# Altium schematic sheet Motors.SchDoc(Motors)
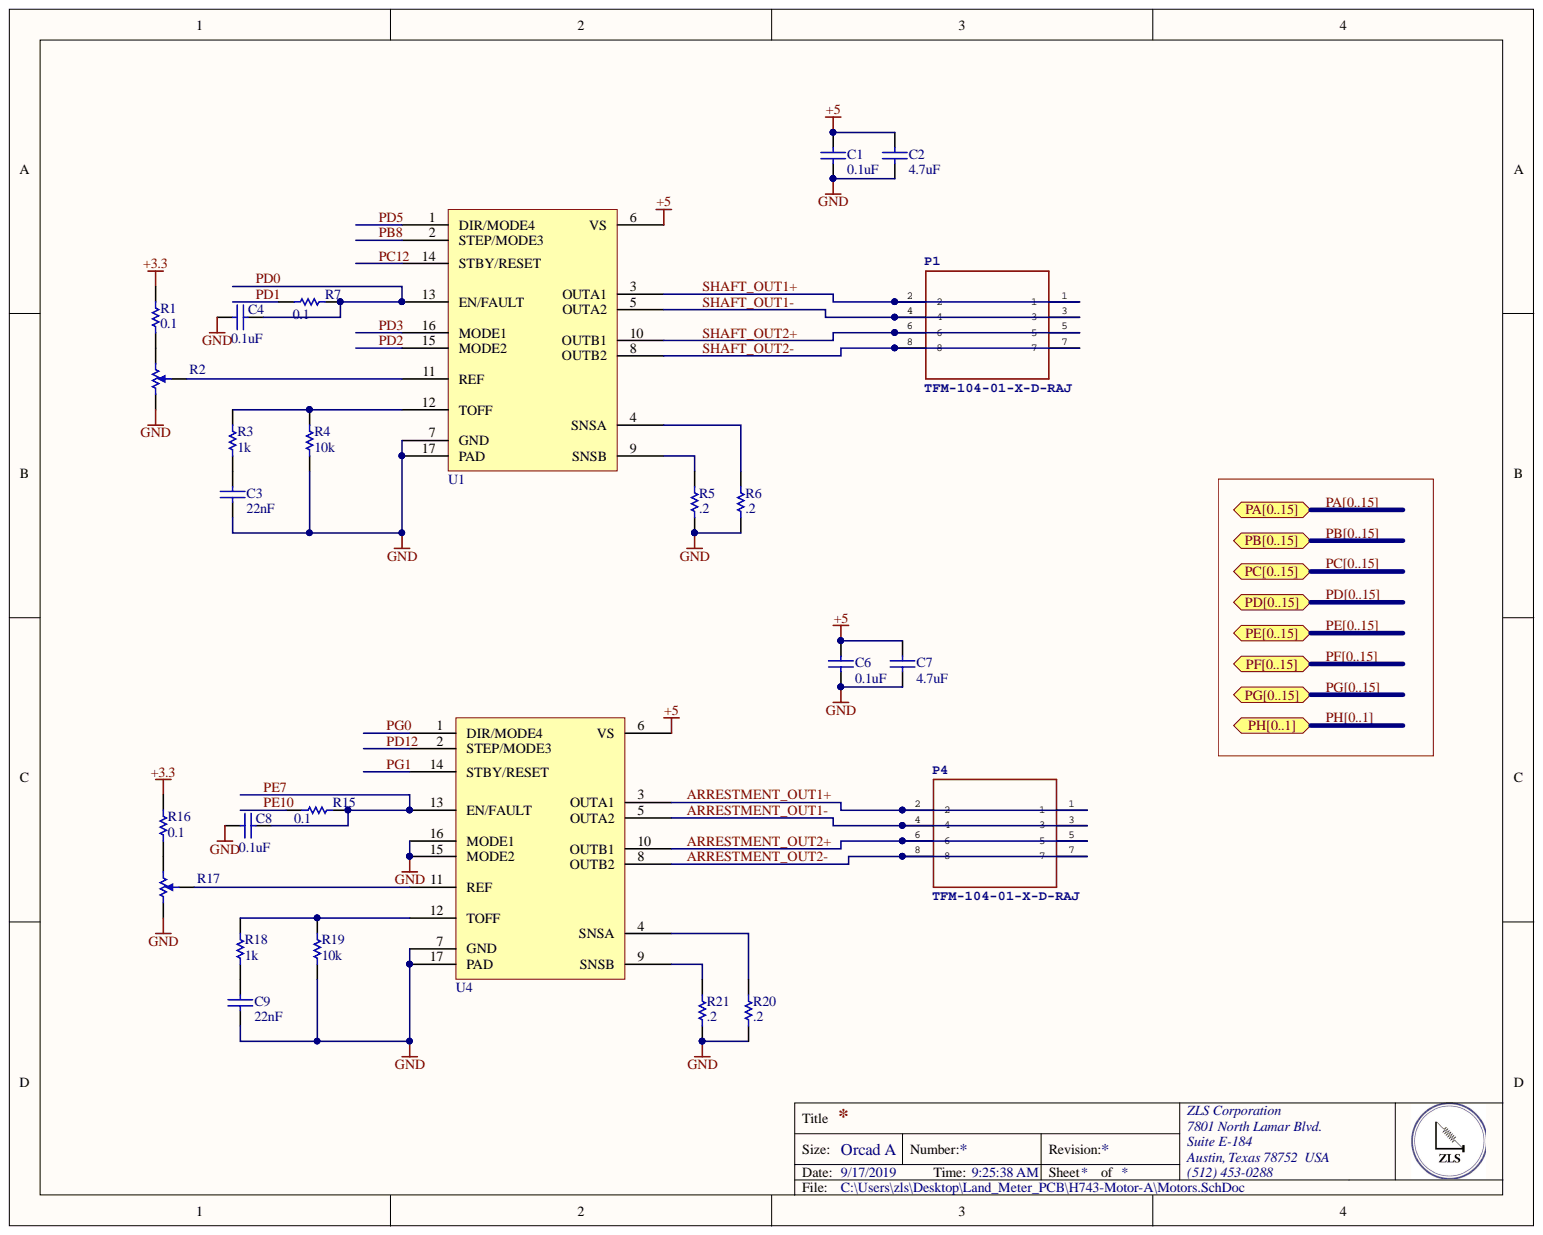
[diagram p0: Motors.SchDoc]

## Parts
C1  0.1uF  [CAPC1608X94X35LL15T15]
C2  4.7uF  [CAPC0603(1608)55_M]
C3  22nF  [CAPC1608X94X35LL15T15]
C4  0.1uF  [CAPC1608X94X35LL15T15]
C6  0.1uF  [CAPC1608X94X35LL15T15]
C7  4.7uF  [CAPC0603(1608)55_M]
C8  0.1uF  [CAPC1608X94X35LL15T15]
C9  22nF  [CAPC1608X94X35LL15T15]
P1  TFM-104-01-X-D-RAJ  [SAMTEC-TFM-104-01-X-D-RA]
P4  TFM-104-01-X-D-RAJ  [SAMTEC-TFM-104-01-X-D-RA]
R1  0.1  [RESC0603(1608)_N]
R2  3313J-1-503E  [BOUR-3313J-1_V]  (value not drawn)
R3  1k  [RESC0603(1608)_N]
R4  10k  [RESC0603(1608)_N]
R5  .2  [RESC0603(1608)_N]
R6  .2  [RESC0603(1608)_N]
R7  0.1  [RESC0603(1608)_N]
R15  0.1  [RESC0603(1608)_N]
R16  0.1  [RESC0603(1608)_N]
R17  3313J-1-503E  [BOUR-3313J-1_V]  (value not drawn)
R18  1k  [RESC0603(1608)_N]
R19  10k  [RESC0603(1608)_N]
R20  .2  [RESC0603(1608)_N]
R21  .2  [RESC0603(1608)_N]
U1  STSPIN220  [STSPIN220-QFN127P600-8N]  (value not drawn)
U4  STSPIN220  [STSPIN220-QFN127P600-8N]  (value not drawn)

## Ports
PA0, PA1, PA2, PA3, PA4, PA5, PA6, PA7, PA8, PA9, PA10, PA11, PA12, PA13, PA14, PA15, PA[0..15], PB0, PB1, PB2, PB3, PB4, PB5, PB6, PB7, PB8, PB9, PB10, PB11, PB12, PB13, PB14, PB15, PB[0..15], PC0, PC1, PC2, PC3, PC4, PC5, PC6, PC7, PC8, PC9, PC10, PC11, PC12, PC13, PC14, PC15, PC[0..15], PD0, PD1, PD2, PD3, PD4, PD5, PD6, PD7, PD8, PD9, PD10, PD11, PD12, PD13, PD14, PD15, PD[0..15], PE0, PE1, PE2, PE3, PE4, PE5, PE6, PE7, PE8, PE9, PE10, PE11, PE12, PE13, PE14, PE15, PE[0..15], PF0, PF1, PF2, PF3, PF4, PF5, PF6, PF7, PF8, PF9, PF10, PF11, PF12, PF13, PF14, PF15, PF[0..15], PG0, PG1, PG2, PG3, PG4, PG5, PG6, PG7, PG8, PG9, PG10, PG11, PG12, PG13, PG14, PG15, PG[0..15], PH0, PH1, PH[0..1]

## Nets
+3.3: R1.1 R16.1
+5: C1.1 C2.2 C6.1 C7.2 U1.6(VS) U4.6(VS)
GND: C1.2 C2.1 C3.2 C4.1 C6.2 C7.1 C8.1 C9.2 R2.1 R4.1 R5.1 R6.1 R17.1 R19.1 R20.1 R21.1 U1.7(GND) U1.17(PAD) U4.7(GND) U4.15(MODE2) U4.16(MODE1) U4.17(PAD)
ARRESTMENT_OUT1+: P4.1 P4.2 U4.3(OUTA1)
ARRESTMENT_OUT1-: P4.3 P4.4 U4.5(OUTA2)
ARRESTMENT_OUT2+: P4.5 P4.6 U4.10(OUTB1)
ARRESTMENT_OUT2-: P4.7 P4.8 U4.8(OUTB2)
PB8: U1.2(STEP/MODE3) ; port PB[0..15]  [also labelled PB]
PC12: U1.14(STBY/RESET) ; port PC[0..15]  [also labelled PC]
PD0: C4.2 R7.1 U1.13(EN/FAULT) ; port PD[0..15]  [also labelled PD]
PD1: R7.2 ; port PD[0..15]  [also labelled PD]
PD2: U1.15(MODE2) ; port PD[0..15]  [also labelled PD]
PD3: U1.16(MODE1) ; port PD[0..15]  [also labelled PD]
PD5: U1.1(DIR/MODE4) ; port PD[0..15]  [also labelled PD]
PD12: U4.2(STEP/MODE3) ; port PD[0..15]  [also labelled PD]
PE7: C8.2 R15.1 U4.13(EN/FAULT) ; port PE[0..15]  [also labelled PE]
PE10: R15.2 ; port PE[0..15]  [also labelled PE]
PG0: U4.1(DIR/MODE4) ; port PG[0..15]  [also labelled PG]
PG1: U4.14(STBY/RESET) ; port PG[0..15]  [also labelled PG]
SHAFT_OUT1+: P1.1 P1.2 U1.3(OUTA1)
SHAFT_OUT1-: P1.3 P1.4 U1.5(OUTA2)
SHAFT_OUT2+: P1.5 P1.6 U1.10(OUTB1)
SHAFT_OUT2-: P1.7 P1.8 U1.8(OUTB2)
NetC3_1: C3.1 R3.1
NetC9_1: C9.1 R18.1
NetR1_2: R1.2 R2.3
NetR2_2: R2.2 U1.11(REF)
NetR3_2: R3.2 R4.2 U1.12(TOFF)
NetR5_2: R5.2 U1.9(SNSB)
NetR6_2: R6.2 U1.4(SNSA)
NetR16_2: R16.2 R17.3
NetR17_2: R17.2 U4.11(REF)
NetR18_2: R18.2 R19.2 U4.12(TOFF)
NetR20_2: R20.2 U4.4(SNSA)
NetR21_2: R21.2 U4.9(SNSB)
PA0: ; port PA[0..15]  [also labelled PA]
PA1: ; port PA[0..15]  [also labelled PA]
PA2: ; port PA[0..15]  [also labelled PA]
PA3: ; port PA[0..15]  [also labelled PA]
PA4: ; port PA[0..15]  [also labelled PA]
PA5: ; port PA[0..15]  [also labelled PA]
PA6: ; port PA[0..15]  [also labelled PA]
PA7: ; port PA[0..15]  [also labelled PA]
PA8: ; port PA[0..15]  [also labelled PA]
PA9: ; port PA[0..15]  [also labelled PA]
PA10: ; port PA[0..15]  [also labelled PA]
PA11: ; port PA[0..15]  [also labelled PA]
PA12: ; port PA[0..15]  [also labelled PA]
PA13: ; port PA[0..15]  [also labelled PA]
PA14: ; port PA[0..15]  [also labelled PA]
PA15: ; port PA[0..15]  [also labelled PA]
PB0: ; port PB[0..15]  [also labelled PB]
PB1: ; port PB[0..15]  [also labelled PB]
PB2: ; port PB[0..15]  [also labelled PB]
PB3: ; port PB[0..15]  [also labelled PB]
PB4: ; port PB[0..15]  [also labelled PB]
PB5: ; port PB[0..15]  [also labelled PB]
PB6: ; port PB[0..15]  [also labelled PB]
PB7: ; port PB[0..15]  [also labelled PB]
PB9: ; port PB[0..15]  [also labelled PB]
PB10: ; port PB[0..15]  [also labelled PB]
PB11: ; port PB[0..15]  [also labelled PB]
PB12: ; port PB[0..15]  [also labelled PB]
PB13: ; port PB[0..15]  [also labelled PB]
PB14: ; port PB[0..15]  [also labelled PB]
PB15: ; port PB[0..15]  [also labelled PB]
PC0: ; port PC[0..15]  [also labelled PC]
PC1: ; port PC[0..15]  [also labelled PC]
PC2: ; port PC[0..15]  [also labelled PC]
PC3: ; port PC[0..15]  [also labelled PC]
PC4: ; port PC[0..15]  [also labelled PC]
PC5: ; port PC[0..15]  [also labelled PC]
PC6: ; port PC[0..15]  [also labelled PC]
PC7: ; port PC[0..15]  [also labelled PC]
PC8: ; port PC[0..15]  [also labelled PC]
PC9: ; port PC[0..15]  [also labelled PC]
PC10: ; port PC[0..15]  [also labelled PC]
PC11: ; port PC[0..15]  [also labelled PC]
PC13: ; port PC[0..15]  [also labelled PC]
PC14: ; port PC[0..15]  [also labelled PC]
PC15: ; port PC[0..15]  [also labelled PC]
PD4: ; port PD[0..15]  [also labelled PD]
PD6: ; port PD[0..15]  [also labelled PD]
PD7: ; port PD[0..15]  [also labelled PD]
PD8: ; port PD[0..15]  [also labelled PD]
PD9: ; port PD[0..15]  [also labelled PD]
PD10: ; port PD[0..15]  [also labelled PD]
PD11: ; port PD[0..15]  [also labelled PD]
PD13: ; port PD[0..15]  [also labelled PD]
PD14: ; port PD[0..15]  [also labelled PD]
PD15: ; port PD[0..15]  [also labelled PD]
PE0: ; port PE[0..15]  [also labelled PE]
PE1: ; port PE[0..15]  [also labelled PE]
PE2: ; port PE[0..15]  [also labelled PE]
PE3: ; port PE[0..15]  [also labelled PE]
PE4: ; port PE[0..15]  [also labelled PE]
PE5: ; port PE[0..15]  [also labelled PE]
PE6: ; port PE[0..15]  [also labelled PE]
PE8: ; port PE[0..15]  [also labelled PE]
PE9: ; port PE[0..15]  [also labelled PE]
PE11: ; port PE[0..15]  [also labelled PE]
PE12: ; port PE[0..15]  [also labelled PE]
PE13: ; port PE[0..15]  [also labelled PE]
PE14: ; port PE[0..15]  [also labelled PE]
PE15: ; port PE[0..15]  [also labelled PE]
PF0: ; port PF[0..15]  [also labelled PF]
PF1: ; port PF[0..15]  [also labelled PF]
PF2: ; port PF[0..15]  [also labelled PF]
PF3: ; port PF[0..15]  [also labelled PF]
PF4: ; port PF[0..15]  [also labelled PF]
PF5: ; port PF[0..15]  [also labelled PF]
PF6: ; port PF[0..15]  [also labelled PF]
PF7: ; port PF[0..15]  [also labelled PF]
PF8: ; port PF[0..15]  [also labelled PF]
PF9: ; port PF[0..15]  [also labelled PF]
PF10: ; port PF[0..15]  [also labelled PF]
PF11: ; port PF[0..15]  [also labelled PF]
PF12: ; port PF[0..15]  [also labelled PF]
PF13: ; port PF[0..15]  [also labelled PF]
PF14: ; port PF[0..15]  [also labelled PF]
PF15: ; port PF[0..15]  [also labelled PF]
PG2: ; port PG[0..15]  [also labelled PG]
PG3: ; port PG[0..15]  [also labelled PG]
PG4: ; port PG[0..15]  [also labelled PG]
PG5: ; port PG[0..15]  [also labelled PG]
PG6: ; port PG[0..15]  [also labelled PG]
PG7: ; port PG[0..15]  [also labelled PG]
PG8: ; port PG[0..15]  [also labelled PG]
PG9: ; port PG[0..15]  [also labelled PG]
PG10: ; port PG[0..15]  [also labelled PG]
PG11: ; port PG[0..15]  [also labelled PG]
PG12: ; port PG[0..15]  [also labelled PG]
PG13: ; port PG[0..15]  [also labelled PG]
PG14: ; port PG[0..15]  [also labelled PG]
PG15: ; port PG[0..15]  [also labelled PG]
PH0: ; port PH[0..1]  [also labelled PH]
PH1: ; port PH[0..1]  [also labelled PH]

## Library data of the parts
C1 C3 C4 C6 C8 C9 = CMP-1661-00001-2: CAP .1UF 16V CERAMIC X7R 0603; Manufacturer Part Number: CC0603KRX7R7BB105; Manufacturer: Yageo; Tolerance: 10%; Dissipation Factor: 5%; Capacitance: 1uF; Width: 0.031inch; Voltage Rating (DC): 16V; Voltage Rating: 16V; Voltage: 16V; Thickness: 0.035inch; Termination: SMD/SMT; Supplier Part Number 1: 311-1446-6-ND; Supplier 1: Digi-Key; Stock 1: 5506121; REACH SVHC: Unknown; Radiation Hardening: No; Pricing 1: 1=0.21, 10=0.143, 100=0.0727, 500=0.04974, 1000=0.044 (USD); Packaging: Tape and Reel; Package Quantity: 1; Number of Pins: 2; Mount: Surface Mount; Min Operating Temperature: -55°C; Max Operating Temperature: 125°C; Library Name: Altium Content Vault; Length: 1.6mm; Lead Free: Lead Free; Height: 0.8mm; Halogen Free: Halogen Free; Dielectric: X7R; Depth: 0.8mm; Composition: Ceramic; ComponentLink5URL: http://www.farnell.com/datasheets/1489800.pdf; ComponentLink5Description: http://www.farnell.com/datasheets/1489800.pdf; ComponentLink4URL: http://www.farnell.com/datasheets/1734573.pdf; ComponentLink4Description: http://www.farnell.com/datasheets/1734573.pdf; ComponentLink3URL: http://www.yageo.com/documents/recent/UPY-GPHC_X7R_6.3V-to-50V_8.pdf; ComponentLink3Description: http://www.yageo.com/documents/recent/UPY-GPHC_X7R_6.3V-to-50V_8.pdf; ComponentLink2URL: http://www.yageo.com/documents/recent/UPY-GPHC_X7R_6.3V-to-50V_18.pdf; ComponentLink2Description: http://www.yageo.com/documents/recent/UPY-GPHC_X7R_6.3V-to-50V_18.pdf; ComponentLink1URL: http://datasheets.avx.com/X7RDielectric.pdf; ComponentLink1Description: Datasheet; Component Kind: Standard; Case Code (Metric): 1608; Case Code (Imperial): 0603; Case/Package: 0603
C2 C7 = CMP-1035-02779-1: CAP 4.7uF 6.3V ±10% 0603 (1608 Metric) Thickness 0.55mm SMD; Manufacturer Part Number: C0603C475K9PACTU; Manufacturer: KEMET; Tolerance: Â±10%; Dissipation Factor: 5%; Dielectric Material: Ceramic; Dielectric: X5R; Case-Metric: 1608; Case-EIA: 0603; Width: 0.031inch; Voltage Rating (DC): 6.3V; Voltage Rating: 6.3V; Voltage: 6.3V; Value: 4.7uF; Thickness: 0.035inch; Termination: SMD/SMT; Technology: SMT; Supplier Part Number 1: 399-3482-6-ND; Supplier 1: Digi-Key; Stock 1: 1765509; REACH SVHC: No SVHC; Rated Voltage: 6.3 V; Radiation Hardening: No; Pricing 1: 1=0.21, 10=0.15, 100=0.0706, 500=0.04952, 1000=0.041 (USD); Packaging: Tape and Reel; Package Quantity: 1; Number of Pins: 2; Mount: PCB,Surface Mount; Min Operating Temperature: -55°C; Max Thickness: 0.55 mm; Max Operating Temperature: 85°C; Library Name: Altium Content Vault; Length: 1.6mm; Lead Pitch: 0.7mm; Lead Free: Lead Free; Height: 0.8mm; Depth: 0.8mm; Contact Plating: Matte,Tin; ComponentLink4URL: http://www.farnell.com/datasheets/40889.pdf; ComponentLink4Description: http://www.farnell.com/datasheets/40889.pdf; ComponentLink3URL: http://www.farnell.com/datasheets/1789732.pdf; ComponentLink3Description: http://www.farnell.com/datasheets/1789732.pdf; ComponentLink2URL: http://www.farnell.com/datasheets/1732700.pdf; ComponentLink2Description: http://www.farnell.com/datasheets/1732700.pdf; ComponentLink1URL: https://api.kemet.com/component-edge/download/datasheet/C0603C475K9PACTU.pdf; ComponentLink1Description: https://api.kemet.com/component-edge/download/datasheet/C0603C475K9PACTU.pdf; Component Kind: Standard; Case Code (Metric): 1608; Case Code (Imperial): 0603; Case/Package: 0603; Capacitance: 4.7uF
P1 P4 = SAMTEC-TFM-104-01-X-D-RAJ: Manufacturer: SAMTEC; Manufacturer Part Number: TFM-104-01-X-D-RAJ; Library Name: SAMTEC-TFM-104-01-X-D-RA.IntLib; Component Kind: Standard
R1 R7 R15 R16 = CMP-1012-00511-1: RES 0.1 OHM 1% 1/10W 0603; Manufacturer Part Number: CRL0603-FW-R100ELF; Manufacturer: Bourns; Temperature Coefficient: 200ppm/°C; Resistance: 100mR; Power Rating: 100mW; Width: 0.8mm; Tolerance: 1%; Termination: SMD/SMT; Supplier Part Number 1: CRL0603-FW-R100ELFCT-ND; Supplier 1: Digi-Key; Stock 1: 25237; RoHS: Yes; REACH SVHC: No SVHC; Radiation Hardening: No; Pricing 1: 1=0.40000, 10=0.28500, 100=0.11400, 1000=0.05616, 2500=0.05203 (USD); Packaging: Tape and Reel; Package Quantity: 1; Number of Terminations: 2; Number of Pins: 2; Mount: Surface Mount; Min Operating Temperature: -55°C; Military Standard: Not; Max Power Dissipation: 100mW; Max Operating Temperature: 125°C; Library Name: Altium Content Vault; Length: 1.6mm; Height: 0.45mm; Depth: 0.8mm; Contact Plating: Tin; Composition: Thick Film; ComponentLink12URL: https://datasheet.ciiva.com/16929/crl-16929692.pdf?src-supplier=Avnet+Europe; ComponentLink12Description: https://datasheet.ciiva.com/16929/crl-16929692.pdf?src-supplier=Avnet+Europe; ComponentLink11URL: https://datasheet.ciiva.com/22693/1896411-22693272.pdf?src-supplier=Element14; ComponentLink11Description: https://datasheet.ciiva.com/22693/1896411-22693272.pdf?src-supplier=Element14; ComponentLink10URL: https://datasheet.ciiva.com/6548/crl-6548262.pdf?src-supplier=Digi-Key; ComponentLink10Description: https://datasheet.ciiva.com/6548/crl-6548262.pdf?src-supplier=Digi-Key; ComponentLink9URL: https://datasheet.ciiva.com/6548/crl-6548261.pdf?src-supplier=Digi-Key; ComponentLink9Description: https://datasheet.ciiva.com/6548/crl-6548261.pdf?src-supplier=Digi-Key; ComponentLink8URL: https://datasheet.ciiva.com/9120/crl-9120710.pdf?src-supplier=Avnet; ComponentLink8Description: https://datasheet.ciiva.com/9120/crl-9120710.pdf?src-supplier=Avnet; ComponentLink7URL: https://datasheet.ciiva.com/11821/0900766b80fcdbbc-11821107.pdf?src-supplier=RSComponents; ComponentLink7Description: https://datasheet.ciiva.com/11821/0900766b80fcdbbc-11821107.pdf?src-supplier=RSComponents; ComponentLink6URL: http://uk.rs-online.com/webdocs/0d98/0900766b80d98afc.pdf; ComponentLink6Description: http://uk.rs-online.com/webdocs/0d98/0900766b80d98afc.pdf; ComponentLink5URL: http://www.farnell.com/datasheets/2111203.pdf; ComponentLink5Description: http://www.farnell.com/datasheets/2111203.pdf; ComponentLink4URL: http://www.vishay.com/docs/20035/dcrcwe3.pdf; ComponentLink4Description: http://www.vishay.com/docs/20035/dcrcwe3.pdf; ComponentLink3URL: http://www.farnell.com/datasheets/2310790.pdf; ComponentLink3Description: http://www.farnell.com/datasheets/2310790.pdf; ComponentLink2URL: https://www.verical.com/server-webapp/html/getDatasheet?partID=86831; ComponentLink2Description: https://www.verical.com/server-webapp/html/getDatasheet?partID=86831; ComponentLink1URL: http://www.vishay.com/docs/20008/dcrcw.pdf; ComponentLink1Description: http://www.vishay.com/docs/20008/dcrcw.pdf; Component Kind: Standard; Case Code (Metric): 1608; Case Code (Imperial): 0603; Case/Package: 0603
R2 R17 = CMP-2000-05588-1: 3 mm Trimpot(R) Trimming Potentiometer, 50 KOhm, +/- 20%, 0.125 W, -55 to 125 degC, 3-Pin SMD, RoHS, Tape and Reel; Manufacturer: Bourns; Voltage Rating (DC): 200V; Track Resistance: 50kohm; Temperature Coefficient: ±150ppm/°C; SVHC: NoSVHC(19-Dec-2012); Resistance: 50kR; Power Rating: 125mW; Number of Turns: 1; Insulation Resistance: 100MR; Width: 0.126inch; Weight: 0.283g; Voltage Rating: 200V; Type: Potentiometer; Tolerance: 20%; Termination: J-Hook; Taper: Linear; Supplier Part Number 1: 3313J-503ECT-ND; Supplier 1: Digi-Key; Stock 1: 7500; RoHS: Yes; Resistance Tolerance: ±20%; REACH SVHC: No SVHC; Radiation Hardening: No; Pricing 1: 1=2.18000, 10=1.91400, 25=1.71600, 50=1.65000, 100=1.58400, 250=1.51800, 500=1.25400 (USD); Potentiometer Mounting: SMD; Packaging: Tape and Reel; Package Reference: 3313J-1; Package Quantity: 1; Package Description: 3-Pin Surface Mount Device, Body 3.5 x 3.2 mm, Pitch 1.15 mm; No. of Turns: 1; Mounting Technology: SurfaceMount; Mount: Surface Mount; Min Operating Temperature: -55°C; Military Standard: Not; Max Power Dissipation: 125mW; Max Operating Temperature: 125°C; Manufacturer URL: http://www.bourns.com/; Manufacturer Part Number: 3313J-1-503E; Library Name: Altium Content Vault; Length: 3.2mm; Height: 2.2mm; Depth: 3.5mm; Datasheet Version: 05/2016; Datasheet URL: http://www.bourns.com/docs/Product-Datasheets/3313.pdf; Composition: Cermet,Potentiometer; ComponentLink12URL: https://datasheet.ciiva.com/48714/001055020-da-01-en-smd-trimm-3313j-1-503e-50k-ohm-0-125w-48714997.pdf?src-supplier=Conrad; ComponentLink12Description: https://datasheet.ciiva.com/48714/001055020-da-01-en-smd-trimm-3313j-1-503e-50k-ohm-0-125w-48714997.pdf?src-supplier=Conrad; ComponentLink11URL: https://datasheet.ciiva.com/30157/9433313-30157869.pdf?src-supplier=Onlinecomponents.com; ComponentLink11Description: https://datasheet.ciiva.com/30157/9433313-30157869.pdf?src-supplier=Onlinecomponents.com; ComponentLink10URL: https://datasheet.ciiva.com/6197/327479-6197404.pdf?src-supplier=Farnell; ComponentLink10Description: https://datasheet.ciiva.com/6197/327479-6197404.pdf?src-supplier=Farnell; ComponentLink9URL: https://datasheet.ciiva.com/3266/3313-3266265.pdf?src-supplier=Avnet; ComponentLink9Description: https://datasheet.ciiva.com/3266/3313-3266265.pdf?src-supplier=Avnet; ComponentLink8URL: https://datasheet.ciiva.com/2156/3313-2156360.pdf?src-supplier=Digi-Key; ComponentLink8Description: https://datasheet.ciiva.com/2156/3313-2156360.pdf?src-supplier=Digi-Key; ComponentLink7URL: https://datasheet.ciiva.com/1477/327479-1477560.pdf?src-supplier=Element14; ComponentLink7Description: https://datasheet.ciiva.com/1477/327479-1477560.pdf?src-supplier=Element14; ComponentLink6URL: https://datasheet.ciiva.com/7400/1864507-7400716.pdf?src-supplier=Newark; ComponentLink6Description: https://datasheet.ciiva.com/7400/1864507-7400716.pdf?src-supplier=Newark; ComponentLink5URL: https://datasheet.ciiva.com/15225/getdatasheetpartid-156979-15225194.pdf?src-supplier=Verical; ComponentLink5Description: https://datasheet.ciiva.com/15225/getdatasheetpartid-156979-15225194.pdf?src-supplier=Verical; ComponentLink4URL: https://datasheet.ciiva.com/2156/3313-2156362.pdf?src-supplier=Digi-Key; ComponentLink4Description: https://datasheet.ciiva.com/2156/3313-2156362.pdf?src-supplier=Digi-Key; ComponentLink3URL: https://datasheet.ciiva.com/2156/3313-2156361.pdf?src-supplier=Digi-Key; ComponentLink3Description: https://datasheet.ciiva.com/2156/3313-2156361.pdf?src-supplier=Digi-Key; ComponentLink2URL: https://datasheet.ciiva.com/36525/1157579-36525954.pdf?src-supplier=Jameco; ComponentLink2Description: https://datasheet.ciiva.com/36525/1157579-36525954.pdf?src-supplier=Jameco; ComponentLink1URL: https://datasheet.ciiva.com/11832/0900766b80dbb89c-11832361.pdf?src-supplier=RSComponents; ComponentLink1Description: https://datasheet.ciiva.com/11832/0900766b80dbb89c-11832361.pdf?src-supplier=RSComponents; Component Kind: Standard; Color: Natural
R3 R18 = CMP-1012-00511-1: RES SMD 1K OHM 0.1% 1/10W 0603; Manufacturer Part Number: ERA-3AEB102V; Manufacturer: Panasonic; Voltage Rating: 75V; Temperature Coefficient: 25ppm/°C; Resistance: 1kR; Power Rating: 100mW; Width: 0.8mm; Tolerance: 0.1%; Termination: SMD/SMT; Supplier Part Number 1: P1.0KDBCT-ND; Supplier 1: Digi-Key; Stock 1: 843980; RoHS: Yes; REACH SVHC: No SVHC; Radiation Hardening: No; Pricing 1: 1=0.35000, 10=0.30000, 100=0.11720, 1000=0.04906, 2500=0.04498 (USD); Packaging: Tape and Reel; Package Quantity: 5000; Number of Terminations: 2; Number of Pins: 2; Mount: Surface Mount; Min Operating Temperature: -55°C; Military Standard: Not; Max Power Dissipation: 100mW; Max Operating Temperature: 155°C; Library Name: Altium Content Vault; Length: 1.6mm; Height: 0.45mm; Depth: 0.8mm; Contact Plating: Tin; Composition: Thin Film; ComponentLink21URL: https://datasheet.ciiva.com/46393/1776116-46393695.pdf?src-supplier=Element14; ComponentLink21Description: https://datasheet.ciiva.com/46393/1776116-46393695.pdf?src-supplier=Element14; ComponentLink20URL: https://datasheet.ciiva.com/16853/aoa0000ce26-16853231.pdf?src-supplier=Avnet+Europe; ComponentLink20Description: https://datasheet.ciiva.com/16853/aoa0000ce26-16853231.pdf?src-supplier=Avnet+Europe; ComponentLink19URL: https://datasheet.ciiva.com/16725/70068849-16725038.pdf?src-supplier=Allied+Electronics; ComponentLink19Description: https://datasheet.ciiva.com/16725/70068849-16725038.pdf?src-supplier=Allied+Electronics; ComponentLink18URL: https://datasheet.ciiva.com/5734/485442-5734525.pdf?src-supplier=Farnell; ComponentLink18Description: https://datasheet.ciiva.com/5734/485442-5734525.pdf?src-supplier=Farnell; ComponentLink17URL: https://datasheet.ciiva.com/9198/aoa0000ce26-9198972.pdf?src-supplier=Avnet; ComponentLink17Description: https://datasheet.ciiva.com/9198/aoa0000ce26-9198972.pdf?src-supplier=Avnet; ComponentLink16URL: https://datasheet.ciiva.com/5734/aoa0000ce26-5734524.pdf?src-supplier=Farnell; ComponentLink16Description: https://datasheet.ciiva.com/5734/aoa0000ce26-5734524.pdf?src-supplier=Farnell; ComponentLink15URL: https://datasheet.ciiva.com/16426/aoa0000ce26-16426809.pdf?src-supplier=Future+Electronics; ComponentLink15Description: https://datasheet.ciiva.com/16426/aoa0000ce26-16426809.pdf?src-supplier=Future+Electronics; ComponentLink14URL: https://datasheet.ciiva.com/28531/485442-28531944.pdf?src-supplier=Element14; ComponentLink14Description: https://datasheet.ciiva.com/28531/485442-28531944.pdf?src-supplier=Element14; ComponentLink13URL: https://datasheet.ciiva.com/46422/485442-46422519.pdf?src-supplier=Element14; ComponentLink13Description: https://datasheet.ciiva.com/46422/485442-46422519.pdf?src-supplier=Element14; ComponentLink12URL: https://datasheet.ciiva.com/46422/1776116-46422455.pdf?src-supplier=Element14; ComponentLink12Description: https://datasheet.ciiva.com/46422/1776116-46422455.pdf?src-supplier=Element14; ComponentLink11URL: https://datasheet.ciiva.com/16781/70068849-16781703.pdf?src-supplier=Allied+Electronics; ComponentLink11Description: https://datasheet.ciiva.com/16781/70068849-16781703.pdf?src-supplier=Allied+Electronics; ComponentLink10URL: https://datasheet.ciiva.com/48530/era-eng-tds-48530582.pdf?src-supplier=Distrelec; ComponentLink10Description: https://datasheet.ciiva.com/48530/era-eng-tds-48530582.pdf?src-supplier=Distrelec; ComponentLink9URL: https://datasheet.ciiva.com/24071/aoa0000ce26-197354-24071510.pdf?src-supplier=Mouser; ComponentLink9Description: https://datasheet.ciiva.com/24071/aoa0000ce26-197354-24071510.pdf?src-supplier=Mouser; ComponentLink8URL: https://datasheet.ciiva.com/29183/450752-da-01-en-widerstand-0603-era-29183754.pdf?src-supplier=Conrad; ComponentLink8Description: https://datasheet.ciiva.com/29183/450752-da-01-en-widerstand-0603-era-29183754.pdf?src-supplier=Conrad; ComponentLink7URL: https://datasheet.ciiva.com/11808/0900766b80fe20f7-11808019.pdf?src-supplier=RSComponents; ComponentLink7Description: https://datasheet.ciiva.com/11808/0900766b80fe20f7-11808019.pdf?src-supplier=RSComponents; ComponentLink6URL: http://uk.rs-online.com/webdocs/0d98/0900766b80d98afc.pdf; ComponentLink6Description: http://uk.rs-online.com/webdocs/0d98/0900766b80d98afc.pdf; ComponentLink5URL: http://www.farnell.com/datasheets/2111203.pdf; ComponentLink5Description: http://www.farnell.com/datasheets/2111203.pdf; ComponentLink4URL: http://www.vishay.com/docs/20035/dcrcwe3.pdf; ComponentLink4Description: http://www.vishay.com/docs/20035/dcrcwe3.pdf; ComponentLink3URL: http://www.farnell.com/datasheets/2310790.pdf; ComponentLink3Description: http://www.farnell.com/datasheets/2310790.pdf; ComponentLink2URL: https://www.verical.com/server-webapp/html/getDatasheet?partID=86831; ComponentLink2Description: https://www.verical.com/server-webapp/html/getDatasheet?partID=86831; ComponentLink1URL: http://www.vishay.com/docs/20008/dcrcw.pdf; ComponentLink1Description: http://www.vishay.com/docs/20008/dcrcw.pdf; Component Kind: Standard; Case Code (Metric): 1608; Case Code (Imperial): 0603; Case/Package: 0603
R4 R19 = CMP-1012-00511-1: 0R12 0.1W 1% 0603 (1608 Metric)  SMD; Manufacturer Part Number: CRCW060310K0FKEA; Manufacturer: Vishay; Voltage Rating (DC): 75V; Value: 1020.00 Ohm; Temperature Coefficient: 100ppm/°C; Resistance: 10kR; Power Rating: 100mW; Case-Metric: 1608; Case-EIA: 0603; Width: 0.033inch; Weight: 7.1E-05oz; Voltage Rating: 75V; Tolerance: 1 %; Termination: SMD/SMT; Technology: SMT; Supplier Part Number 1: 311-0.0GRDKR-ND; Supplier 1: Digi-Key; Stock 1: 49641653; REACH SVHC: Unknown; Radiation Hardening: No; Pricing 1: 1=0.1, 10=0.021, 25=0.0152, 50=0.0114, 100=0.0084, 250=0.00644, 500=0.00514, 1000=0.00379 (USD); Power: 0.1 W; Packaging: Tape and Reel; Package Quantity: 10; Number of Terminations: 2; Number of Pins: 2; Min Operating Temperature: -55°C; Military Standard: Not; Max Power Dissipation: 100mW; Max Operating Temperature: 155°C; Library Name: Altium Content Vault; Length: 1.55mm; Lead Free: Lead Free; Height: 0.45mm; Features: Automotive AEC-Q200; Depth: 0.85mm; Contact Plating: Lead,Tin; Composition: Thick Film; ComponentLink6URL: http://uk.rs-online.com/webdocs/0d98/0900766b80d98afc.pdf; ComponentLink6Description: http://uk.rs-online.com/webdocs/0d98/0900766b80d98afc.pdf; ComponentLink5URL: http://www.farnell.com/datasheets/2111203.pdf; ComponentLink5Description: http://www.farnell.com/datasheets/2111203.pdf; ComponentLink4URL: http://www.vishay.com/docs/20035/dcrcwe3.pdf; ComponentLink4Description: http://www.vishay.com/docs/20035/dcrcwe3.pdf; ComponentLink3URL: http://www.farnell.com/datasheets/2310790.pdf; ComponentLink3Description: http://www.farnell.com/datasheets/2310790.pdf; ComponentLink2URL: https://www.verical.com/server-webapp/html/getDatasheet?partID=86831; ComponentLink2Description: https://www.verical.com/server-webapp/html/getDatasheet?partID=86831; ComponentLink1URL: http://www.vishay.com/docs/20008/dcrcw.pdf; ComponentLink1Description: http://www.vishay.com/docs/20008/dcrcw.pdf; Component Kind: Standard; Case Code (Metric): 1608; Case Code (Imperial): 0603; Case/Package: 0603
R5 R6 R20 R21 = CMP-1012-00511-1: RES 0.1W 1% 0603 (1608 Metric)  SMD; Manufacturer Part Number: CRL0603-FW-R200ELF; Manufacturer: Bourns; Temperature Coefficient: 200ppm/°C; Resistance: 200mR; Power Rating: 100mW; Width: 0.031inch; Tolerance: 1%; Termination: SMD/SMT; Supplier Part Number 1: CRL0603-FW-R200ELFCT-ND; Supplier 1: Digi-Key; Stock 1: 471; RoHS: Yes; REACH SVHC: No SVHC; Radiation Hardening: No; Pricing 1: 1=0.40000, 10=0.28500, 100=0.11400, 1000=0.05616, 2500=0.05203 (USD); Packaging: Tape and Reel; Package Quantity: 5000; Number of Terminations: 2; Number of Pins: 2; Mount: Surface Mount; Min Operating Temperature: -55°C; Military Standard: Not; Max Power Dissipation: 100mW; Max Operating Temperature: 125°C; Library Name: Altium Content Vault; Length: 1.6mm; Height: 0.45mm; Depth: 0.8mm; Contact Plating: Tin; Composition: Thick Film; ComponentLink8URL: https://datasheet.ciiva.com/9120/crl-9120264.pdf?src-supplier=Avnet; ComponentLink8Description: https://datasheet.ciiva.com/9120/crl-9120264.pdf?src-supplier=Avnet; ComponentLink7URL: https://datasheet.ciiva.com/16929/crl-16929701.pdf?src-supplier=Avnet+Europe; ComponentLink7Description: https://datasheet.ciiva.com/16929/crl-16929701.pdf?src-supplier=Avnet+Europe; ComponentLink6URL: http://uk.rs-online.com/webdocs/0d98/0900766b80d98afc.pdf; ComponentLink6Description: http://uk.rs-online.com/webdocs/0d98/0900766b80d98afc.pdf; ComponentLink5URL: http://www.farnell.com/datasheets/2111203.pdf; ComponentLink5Description: http://www.farnell.com/datasheets/2111203.pdf; ComponentLink4URL: http://www.vishay.com/docs/20035/dcrcwe3.pdf; ComponentLink4Description: http://www.vishay.com/docs/20035/dcrcwe3.pdf; ComponentLink3URL: http://www.farnell.com/datasheets/2310790.pdf; ComponentLink3Description: http://www.farnell.com/datasheets/2310790.pdf; ComponentLink2URL: https://www.verical.com/server-webapp/html/getDatasheet?partID=86831; ComponentLink2Description: https://www.verical.com/server-webapp/html/getDatasheet?partID=86831; ComponentLink1URL: http://www.vishay.com/docs/20008/dcrcw.pdf; ComponentLink1Description: http://www.vishay.com/docs/20008/dcrcw.pdf; Component Kind: Standard; Case Code (Metric): 1608; Case Code (Imperial): 0603; Case/Package: 0603
U1 U4 = STSPIN220: LOW VOLTAGE STEPPER MOTOR DRIVER; Manufacturer: STMicroelectronics; Supplier Part Number 1: 497-16602-1-ND; Supplier 1: Digi-Key; Stock 1: 3719; RoHS: No; Pricing 1: 1=2.60000, 10=2.33400, 100=1.87580, 500=1.54114, 1000=1.27694 (USD); Manufacturer Part Number: STSPIN220; ComponentLink1URL: http://www.st.com/content/ccc/resource/technical/document/datasheet/group3/42/7e/5c/90/70/ef/47/95/DM00291865/files/DM00291865.pdf/jcr:content/translations/en.DM00291865.pdf?src-supplier=Digi-Key; ComponentLink1Description: http://www.st.com/content/ccc/resource/technical/document/datasheet/group3/42/7e/5c/90/70/ef/47/95/DM00291865/files/DM00291865.pdf/jcr:content/translations/en.DM00291865.pdf?src-supplier=Digi-Key; Component Kind: Standard; Library Name: ZLS_Schematic_lib.SchLib
